# Altium schematic sheet Sheet1.SchDoc(Sheet1)
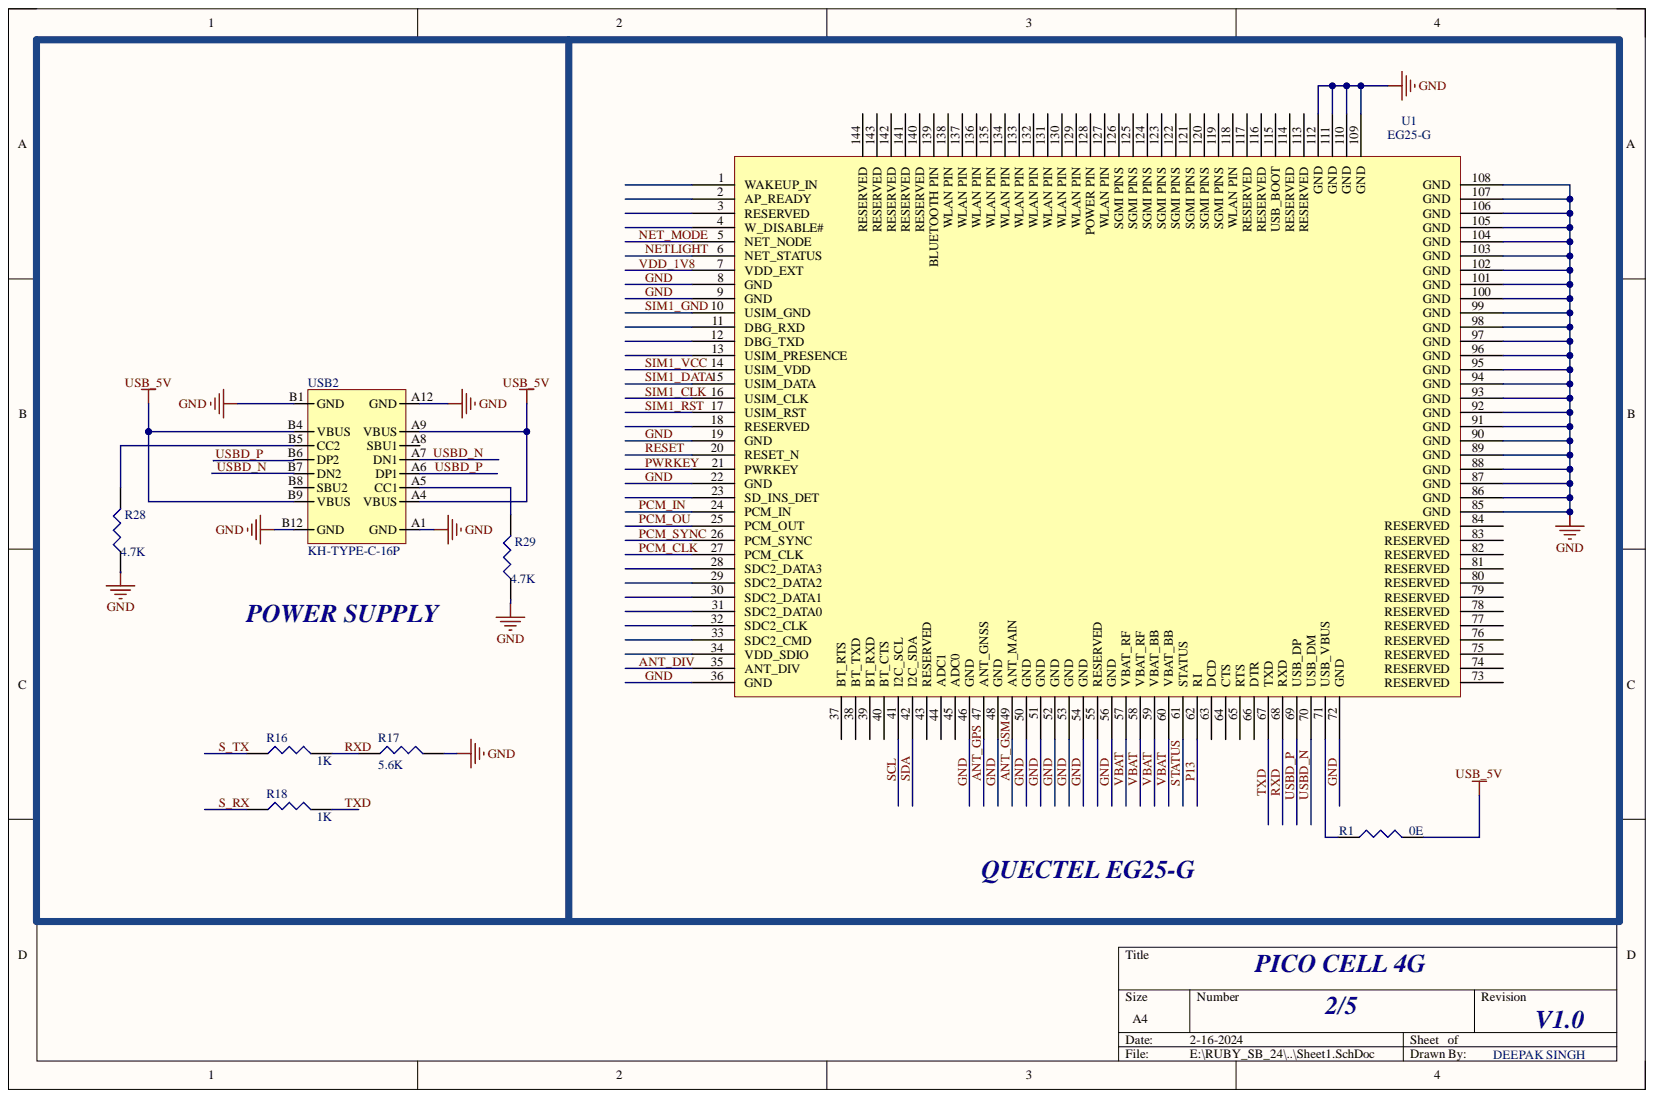
[diagram p0: Sheet1.SchDoc]

## Parts
R1  0E  [0603]
R16  1K  [R_0603]
R17  5.6K  [R_0603]
R18  1K  [R_0603]
R28  4.7K  [R_0603]
R29  4.7K  [R_0603]
U1  EG25-G  [QUECTEL 4G]
USB2  KH-TYPE-C-16P  [USB TYPE C]

## Nets
USB_5V: R1.2 USB2.A4(VBUS) USB2.A9(VBUS) USB2.B4(VBUS) USB2.B9(VBUS)
ANT_DIV: U1.35(ANT_DIV)
ANT_GPS: U1.47(ANT_GNSS)
ANT_GSM: U1.49(ANT_MAIN)
GND: R17.2 R28.1 R29.1 U1.8(GND) U1.9(GND) U1.19(GND) U1.22(GND) U1.36(GND) U1.46(GND) U1.48(GND) U1.50(GND) U1.51(GND) U1.52(GND) U1.53(GND) U1.54(GND) U1.56(GND) U1.72(GND) U1.85(GND) U1.86(GND) U1.87(GND) U1.88(GND) U1.89(GND) U1.90(GND) U1.91(GND) U1.92(GND) U1.93(GND) U1.94(GND) U1.95(GND) U1.96(GND) U1.97(GND) U1.98(GND) U1.99(GND) U1.100(GND) U1.101(GND) U1.102(GND) U1.103(GND) U1.104(GND) U1.105(GND) U1.106(GND) U1.107(GND) U1.108(GND) U1.109(GND) U1.110(GND) U1.111(GND) U1.112(GND) USB2.A1(GND) USB2.A12(GND) USB2.B1(GND) USB2.B12(GND)
NET_MODE: U1.5(NET_NODE)
NETLIGHT: U1.6(NET_STATUS)
P13: U1.62(RI)
PCM_CLK: U1.27(PCM_CLK)
PCM_IN: U1.24(PCM_IN)
PCM_OU: U1.25(PCM_OUT)
PCM_SYNC: U1.26(PCM_SYNC)
PWRKEY: U1.21(PWRKEY)
RESET: U1.20(RESET_N)
RXD: R16.2 R17.1 U1.68(RXD)
S_RX: R18.1
S_TX: R16.1
SCL: U1.41(I2C_SCL)
SDA: U1.42(I2C_SDA)
SIM1_CLK: U1.16(USIM_CLK)
SIM1_DATA: U1.15(USIM_DATA)
SIM1_GND: U1.10(USIM_GND)
SIM1_RST: U1.17(USIM_RST)
SIM1_VCC: U1.14(USIM_VDD)
STATUS: U1.61(STATUS)
TXD: R18.2 U1.67(TXD)
USBD_N: U1.70(USB_DM) USB2.A7(DN1) USB2.B7(DN2)
USBD_P: U1.69(USB_DP) USB2.A6(DP1) USB2.B6(DP2)
VBAT: U1.57(VBAT_RF) U1.58(VBAT_RF) U1.59(VBAT_BB) U1.60(VBAT_BB)
VDD_1V8: U1.7(VDD_EXT)
NetR1_1: R1.1 U1.71(USB_VBUS)
NetR28_2: R28.2 USB2.B5(CC2)
NetR29_2: R29.2 USB2.A5(CC1)

Not connected: U1 1(WAKEUP_IN) 2(AP_READY) 3(RESERVED) 4(W_DISABLE#) 11(DBG_RXD) 12(DBG_TXD) 13(USIM_PRESENCE) 18(RESERVED) 23(SD_INS_DET) 28(SDC2_DATA3) 29(SDC2_DATA2) 30(SDC2_DATA1) 31(SDC2_DATA0) 32(SDC2_CLK) 33(SDC2_CMD) 34(VDD_SDIO) 37(BT_RTS) 38(BT_TXD) 39(BT_RXD) 40(BT_CTS) 43(RESERVED) 44(ADC1) 45(ADC0) 55(RESERVED) 63(DCD) 64(CTS) 65(RTS) 66(DTR) 73(RESERVED) 74(RESERVED) 75(RESERVED) 76(RESERVED) 77(RESERVED) 78(RESERVED) 79(RESERVED) 80(RESERVED) 81(RESERVED) 82(RESERVED) 83(RESERVED) 84(RESERVED) 113(RESERVED) 114(RESERVED) 115(USB_BOOT) 116(RESERVED) 117(RESERVED) 118(WLAN_PIN) 119(SGMI_PINS) 120(SGMI_PINS) 121(SGMI_PINS) 122(SGMI_PINS) 123(SGMI_PINS) 124(SGMI_PINS) 125(SGMI_PINS) 126(SGMI_PINS) 127(WLAN_PIN) 128(POWER_PIN) 129(WLAN_PIN) 130(WLAN_PIN) 131(WLAN_PIN) 132(WLAN_PIN) 133(WLAN_PIN) 134(WLAN_PIN) 135(WLAN_PIN) 136(WLAN_PIN) 137(WLAN_PIN) 138(WLAN_PIN) 139(BLUETOOTH_PIN) 140(RESERVED) 141(RESERVED) 142(RESERVED) 143(RESERVED) 144(RESERVED); USB2 A8(SBU1) B8(SBU2)

## Library data of the parts
R1 R16-R18 R28 R29 = RES: Component Kind: Standard; Library Name: dxp_pasl_lib.IntLib
USB2 = USB TYPE-C KH-TYPE-C-16P: 3A 1 Surface Mount 16 Female -40?~+85? Type-C SMD USB Connectors ROHS #  C709357; Component Kind: Standard; ComponentLink2Description: New link; ComponentLink2URL: https://lcsc.com/product-detail/USB-Connectors_Shenzhen-Kinghelm-Elec-KH-TYPE-C-16P_C709357.html; Supplier 1: LCSC; Supplier Part Number 1: C165948; Library Name: dxp_pasl_lib.IntLib
U1 = EG25-G: Component Kind: Standard; Library Name: dxp_pasl_lib.IntLib
